# Altium schematic sheet 419-005-01-02_PG 10 - STRAPPING_JTAG_SD_&_Terminal.SchDoc("419-005-01-02_PG 10 - STRAPPING_JTAG_SD_&_Terminal")
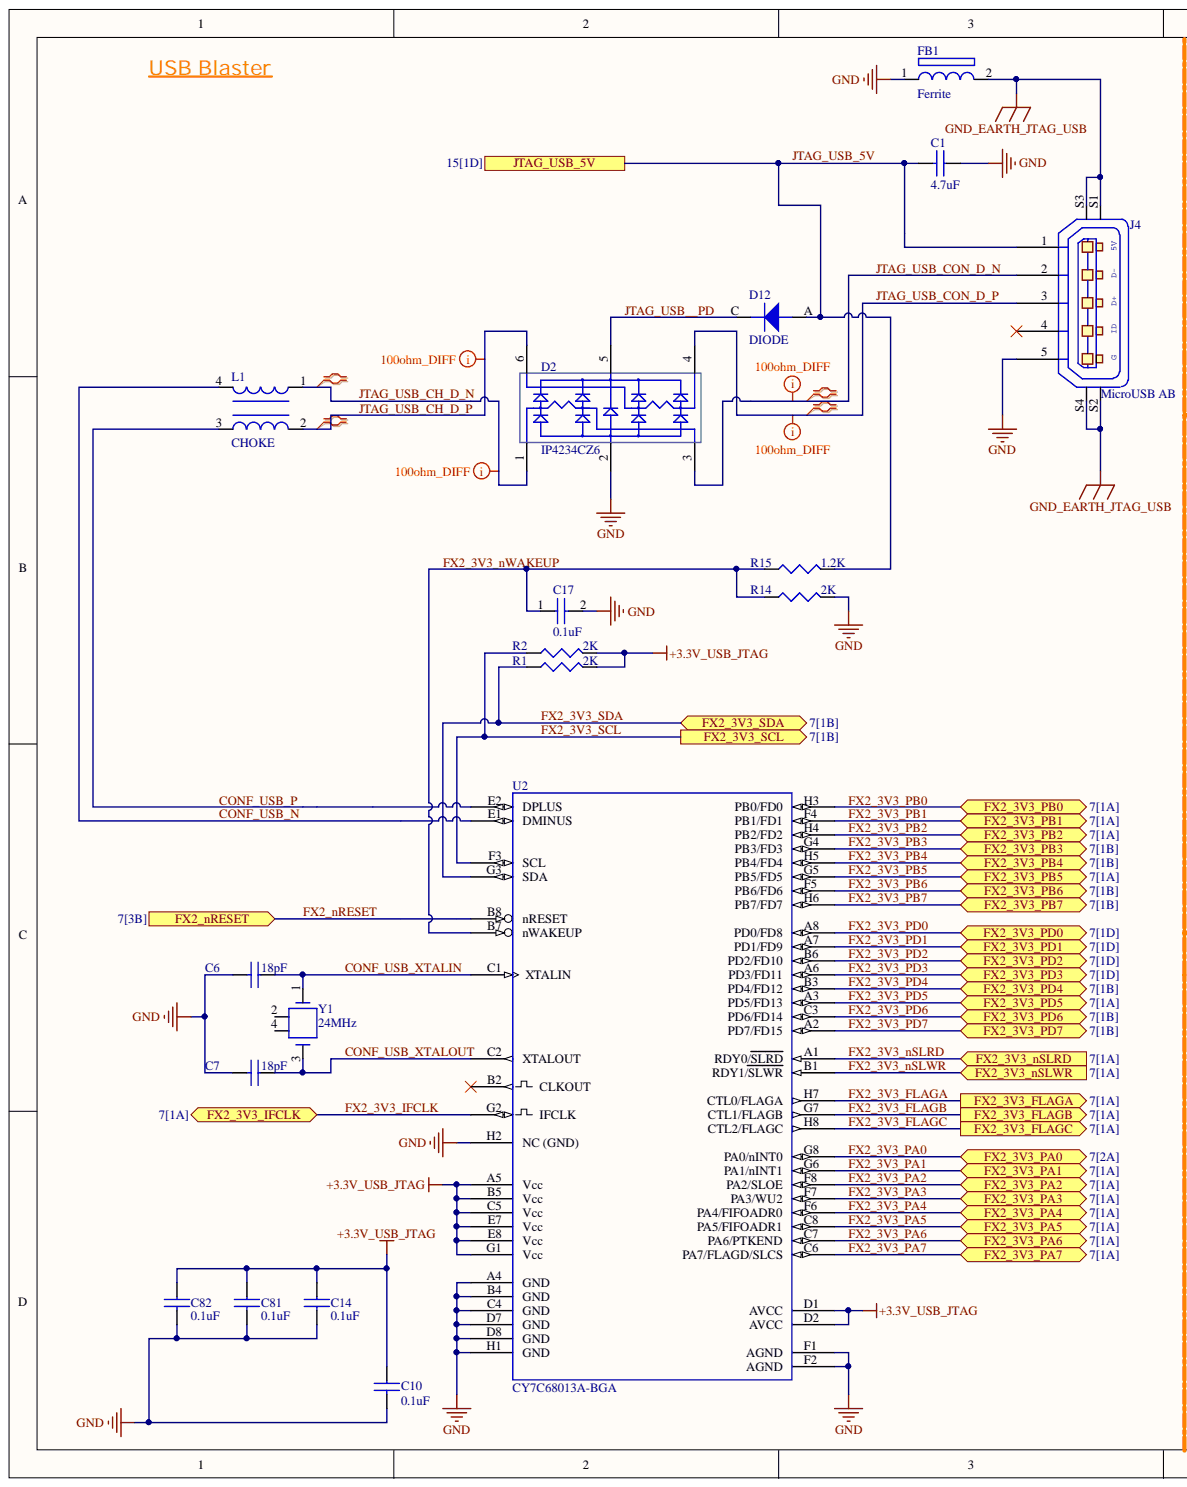
[diagram p0: 419-005-01-02_PG 10 - STRAPPING_JTAG_SD_&_Terminal.SchDoc, left]
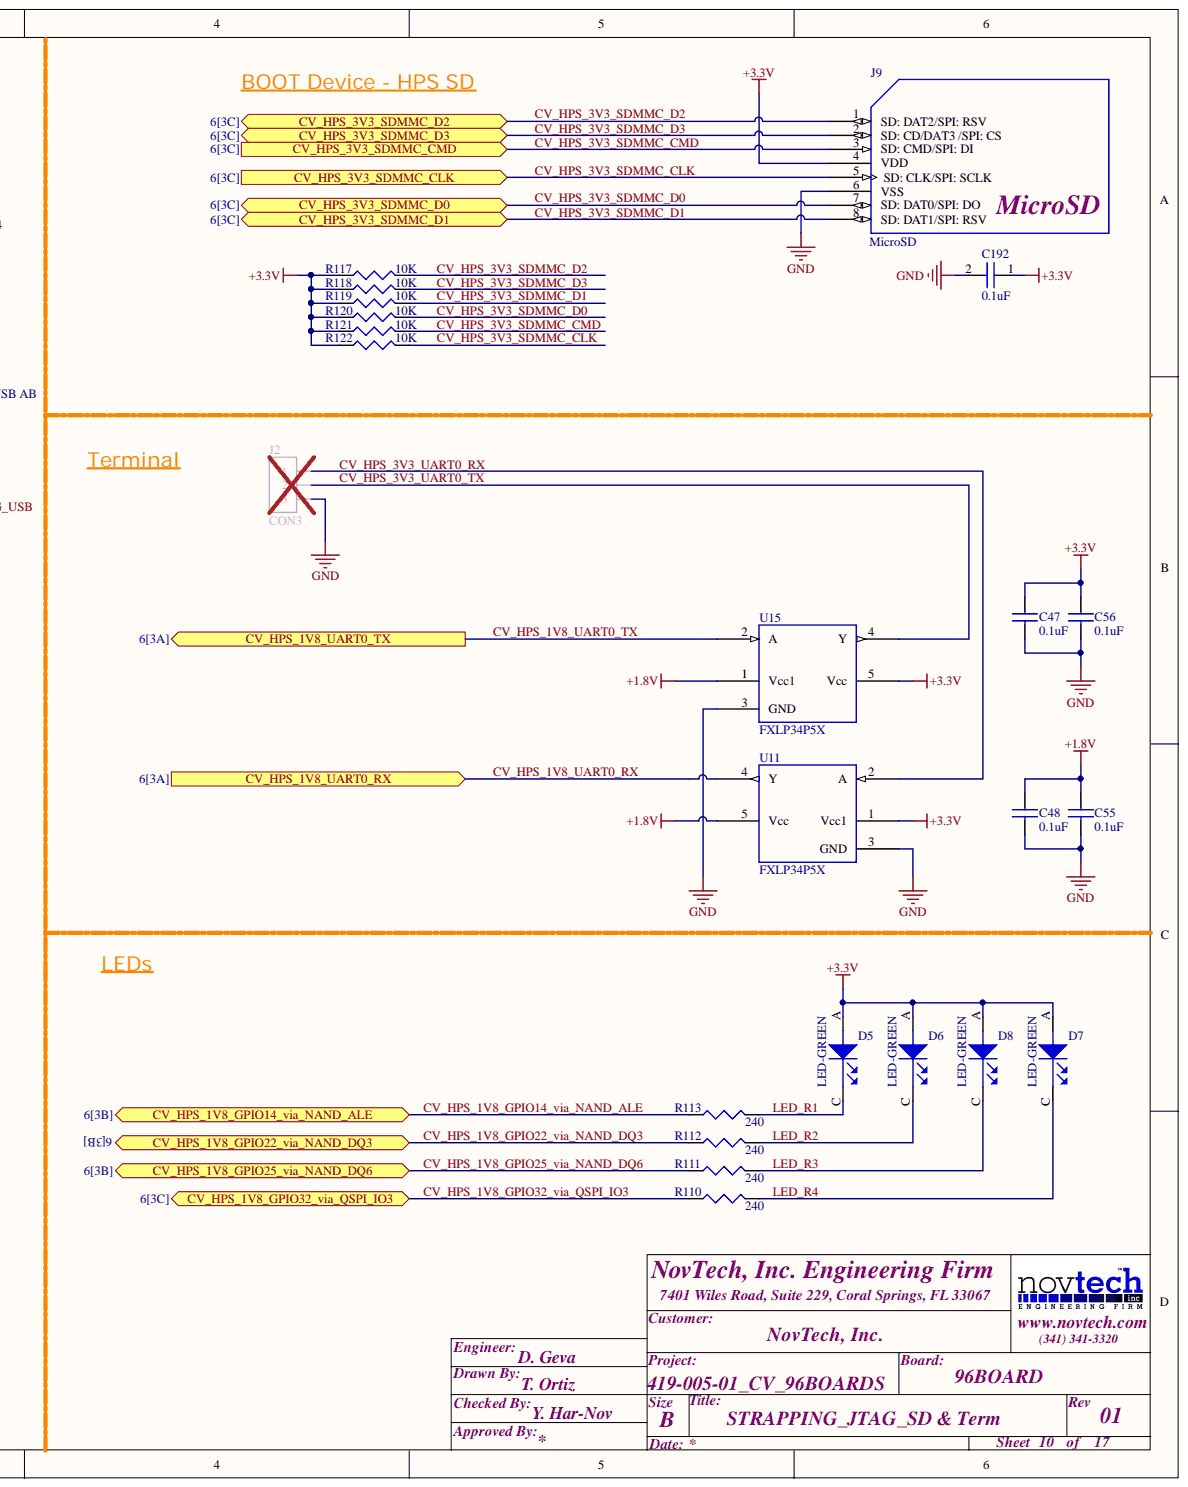
[diagram p1: 419-005-01-02_PG 10 - STRAPPING_JTAG_SD_&_Terminal.SchDoc, right]

## Parts
C1  4.7uF  [0402_C]
C6  18pF  [0201_C]
C7  18pF  [0201_C]
C10  0.1uF  [0201_C]
C14  0.1uF  [0201_C]
C17  0.1uF  [0201_C]
C47  0.1uF  [0201_C]
C48  0.1uF  [0201_C]
C55  0.1uF  [0201_C]
C56  0.1uF  [0201_C]
C81  0.1uF  [0201_C]
C82  0.1uF  [0201_C]
C192  0.1uF  [0201_C]
D2  IP4234CZ6  [SC-74 (SOT457)]
D5  LED-GREEN  [0603_LED]
D6  LED-GREEN  [0603_LED]
D7  LED-GREEN  [0603_LED]
D8  LED-GREEN  [0603_LED]
D12  DIODE  [0402_D]
FB1  Ferrite  [0402_R]
J2  CON3
J4  MicroUSB AB  [MICROUSB_AB_475890001]
J9  MicroSD  [MicroSD - MSPN09-08-D]
L1  CHOKE  [CHOKE_ACM2012_0805]
R1  2K  [0201_R]
R2  2K  [0201_R]
R14  2K  [0201_R]
R15  1.2K  [0201_R]
R110  240  [0201_R]
R111  240  [0201_R]
R112  240  [0201_R]
R113  240  [0201_R]
R117  10K  [0201_R]
R118  10K  [0201_R]
R119  10K  [0201_R]
R120  10K  [0201_R]
R121  10K  [0201_R]
R122  10K  [0201_R]
U2  CY7C68013A-BGA  [VFBGA56 (5.0mmX5.0mm 0.5mm)]
U11  FXLP34P5X  [SC70-5 DCK]
U15  FXLP34P5X  [SC70-5 DCK]
Y1  24MHz  [OSC_SMT_1.6X1.2]

## Ports
CV_HPS_1V8_GPIO14_VIA_NAND_ALE, CV_HPS_1V8_GPIO22_VIA_NAND_DQ3, CV_HPS_1V8_GPIO25_VIA_NAND_DQ6, CV_HPS_1V8_GPIO32_VIA_QSPI_IO3, CV_HPS_1V8_UART0_RX, CV_HPS_1V8_UART0_TX, CV_HPS_3V3_SDMMC_CLK, CV_HPS_3V3_SDMMC_CMD, CV_HPS_3V3_SDMMC_D0, CV_HPS_3V3_SDMMC_D1, CV_HPS_3V3_SDMMC_D2, CV_HPS_3V3_SDMMC_D3, FX2_3V3_FLAGA, FX2_3V3_FLAGB, FX2_3V3_FLAGC, FX2_3V3_IFCLK, FX2_3V3_NSLRD, FX2_3V3_NSLWR, FX2_3V3_PA0, FX2_3V3_PA1, FX2_3V3_PA2, FX2_3V3_PA3, FX2_3V3_PA4, FX2_3V3_PA5, FX2_3V3_PA6, FX2_3V3_PA7, FX2_3V3_PB0, FX2_3V3_PB1, FX2_3V3_PB2, FX2_3V3_PB3, FX2_3V3_PB4, FX2_3V3_PB5, FX2_3V3_PB6, FX2_3V3_PB7, FX2_3V3_PD0, FX2_3V3_PD1, FX2_3V3_PD2, FX2_3V3_PD3, FX2_3V3_PD4, FX2_3V3_PD5, FX2_3V3_PD6, FX2_3V3_PD7, FX2_3V3_SCL, FX2_3V3_SDA, FX2_NRESET, JTAG_USB_5V

## Nets
+1.8V: C48.1 C55.1 U11.5(Vcc) U15.1(Vcc1)
+3.3V: C47.1 C56.1 C192.1 D5.A D6.A D7.A D8.A J9.4(VDD) R117.1 R118.1 R119.1 R120.1 R121.1 R122.1 U11.1(Vcc1) U15.5(Vcc)
+3.3V_USB_JTAG: C10.1 C14.1 C81.1 C82.1 R1.2 R2.2 U2.A5(Vcc) U2.B5(Vcc) U2.C5(Vcc) U2.D1(AVCC) U2.D2(AVCC) U2.E7(Vcc) U2.E8(Vcc) U2.G1(Vcc)
GND: C1.1 C6.2 C7.2 C10.2 C14.2 C17.2 C47.2 C48.2 C55.2 C56.2 C81.2 C82.2 C192.2 D2.2 FB1.1 J2.3 J4.5 J9.6(VSS) R14.2 U2.A4(GND) U2.B4(GND) U2.C4(GND) U2.D7(GND) U2.D8(GND) U2.F1(AGND) U2.F2(AGND) U2.H1(GND) U2.H2(NC_(GND)) U11.3(GND) U15.3(GND)
GND_EARTH_JTAG_USB: FB1.2 J4.S1 J4.S2 J4.S3 J4.S4
CONF_USB_N: L1.4 U2.E1(DMINUS)
CONF_USB_P: L1.3 U2.E2(DPLUS)
CONF_USB_XTALIN: C6.1 U2.C1(XTALIN) Y1.1
CONF_USB_XTALOUT: C7.1 U2.C2(XTALOUT) Y1.3
CV_HPS_1V8_GPIO14_VIA_NAND_ALE: R113.1 ; port CV_HPS_1V8_GPIO14_VIA_NAND_ALE
CV_HPS_1V8_GPIO22_VIA_NAND_DQ3: R112.1 ; port CV_HPS_1V8_GPIO22_VIA_NAND_DQ3
CV_HPS_1V8_GPIO25_VIA_NAND_DQ6: R111.1 ; port CV_HPS_1V8_GPIO25_VIA_NAND_DQ6
CV_HPS_1V8_GPIO32_VIA_QSPI_IO3: R110.1 ; port CV_HPS_1V8_GPIO32_VIA_QSPI_IO3
CV_HPS_1V8_UART0_RX: U11.4(Y) ; port CV_HPS_1V8_UART0_RX
CV_HPS_1V8_UART0_TX: U15.2(A) ; port CV_HPS_1V8_UART0_TX
CV_HPS_3V3_SDMMC_CLK: J9.5(SD:_CLK/SPI:_SCLK) R122.2 ; port CV_HPS_3V3_SDMMC_CLK
CV_HPS_3V3_SDMMC_CMD: J9.3(SD:_CMD/SPI:_DI) R121.2 ; port CV_HPS_3V3_SDMMC_CMD
CV_HPS_3V3_SDMMC_D0: J9.7(SD:_DAT0/SPI:_DO) R120.2 ; port CV_HPS_3V3_SDMMC_D0
CV_HPS_3V3_SDMMC_D1: J9.8(SD:_DAT1/SPI:_RSV) R119.2 ; port CV_HPS_3V3_SDMMC_D1
CV_HPS_3V3_SDMMC_D2: J9.1(SD:_DAT2/SPI:_RSV) R117.2 ; port CV_HPS_3V3_SDMMC_D2
CV_HPS_3V3_SDMMC_D3: J9.2(SD:_CD/DAT3_/SPI:_CS) R118.2 ; port CV_HPS_3V3_SDMMC_D3
CV_HPS_3V3_UART0_RX: J2.1 U11.2(A)
CV_HPS_3V3_UART0_TX: J2.2 U15.4(Y)
FX2_3V3_FLAGA: U2.H7(CTL0/FLAGA) ; port FX2_3V3_FLAGA
FX2_3V3_FLAGB: U2.G7(CTL1/FLAGB) ; port FX2_3V3_FLAGB
FX2_3V3_FLAGC: U2.H8(CTL2/FLAGC) ; port FX2_3V3_FLAGC
FX2_3V3_IFCLK: U2.G2 ; port FX2_3V3_IFCLK
FX2_3V3_NSLRD: U2.A1(RDY0/SLRD) ; port FX2_3V3_NSLRD
FX2_3V3_NSLWR: U2.B1(RDY1/SLWR) ; port FX2_3V3_NSLWR
FX2_3V3_NWAKEUP: C17.1 R14.1 R15.1 U2.B7(nWAKEUP)
FX2_3V3_PA0: U2.G8(PA0/nINT0) ; port FX2_3V3_PA0
FX2_3V3_PA1: U2.G6(PA1/nINT1) ; port FX2_3V3_PA1
FX2_3V3_PA2: U2.F8(PA2/SLOE) ; port FX2_3V3_PA2
FX2_3V3_PA3: U2.F7(PA3/WU2) ; port FX2_3V3_PA3
FX2_3V3_PA4: U2.F6(PA4/FIFOADR0) ; port FX2_3V3_PA4
FX2_3V3_PA5: U2.C8(PA5/FIFOADR1) ; port FX2_3V3_PA5
FX2_3V3_PA6: U2.C7(PA6/PTKEND) ; port FX2_3V3_PA6
FX2_3V3_PA7: U2.C6(PA7/FLAGD/SLCS) ; port FX2_3V3_PA7
FX2_3V3_PB0: U2.H3(PB0/FD0) ; port FX2_3V3_PB0
FX2_3V3_PB1: U2.F4(PB1/FD1) ; port FX2_3V3_PB1
FX2_3V3_PB2: U2.H4(PB2/FD2) ; port FX2_3V3_PB2
FX2_3V3_PB3: U2.G4(PB3/FD3) ; port FX2_3V3_PB3
FX2_3V3_PB4: U2.H5(PB4/FD4) ; port FX2_3V3_PB4
FX2_3V3_PB5: U2.G5(PB5/FD5) ; port FX2_3V3_PB5
FX2_3V3_PB6: U2.F5(PB6/FD6) ; port FX2_3V3_PB6
FX2_3V3_PB7: U2.H6(PB7/FD7) ; port FX2_3V3_PB7
FX2_3V3_PD0: U2.A8(PD0/FD8) ; port FX2_3V3_PD0
FX2_3V3_PD1: U2.A7(PD1/FD9) ; port FX2_3V3_PD1
FX2_3V3_PD2: U2.B6(PD2/FD10) ; port FX2_3V3_PD2
FX2_3V3_PD3: U2.A6(PD3/FD11) ; port FX2_3V3_PD3
FX2_3V3_PD4: U2.B3(PD4/FD12) ; port FX2_3V3_PD4
FX2_3V3_PD5: U2.A3(PD5/FD13) ; port FX2_3V3_PD5
FX2_3V3_PD6: U2.C3(PD6/FD14) ; port FX2_3V3_PD6
FX2_3V3_PD7: U2.A2(PD7/FD15) ; port FX2_3V3_PD7
FX2_3V3_SCL: R2.1 U2.F3(SCL) ; port FX2_3V3_SCL
FX2_3V3_SDA: R1.1 U2.G3(SDA) ; port FX2_3V3_SDA
FX2_NRESET: U2.B8(nRESET) ; port FX2_NRESET
JTAG_USB_5V: C1.2 D12.A J4.1 R15.2 ; port JTAG_USB_5V
JTAG_USB__PD: D2.5 D12.C
JTAG_USB_CH_D_N: D2.1 L1.1
JTAG_USB_CH_D_P: D2.6 L1.2
JTAG_USB_CON_D_N: D2.3 J4.2
JTAG_USB_CON_D_P: D2.4 J4.3
LED_R1: D5.C R113.2
LED_R2: D6.C R112.2
LED_R3: D8.C R111.2
LED_R4: D7.C R110.2

Not connected: J4 4; U2 B2; Y1 2 4

## Library data of the parts
C1 = CAP_0402: Capasitor 0402 footprint; Bottom: 10; Right: 20; Component Kind: Standard; Library Name: PASSIVES.SchLib
C6 C7 C10 C14 C17 C47 C48 C55 C56 C81 C82 C192 = CAP_0201: Capasitor 0201 footprint; Component Kind: Standard; Library Name: PASSIVES.SchLib
D2 = IP4234CZ6: PART: IP4234CZ6,125; MFG: NXP; TEMP: -40°C to +85°C; TYPE: SMT; Component Kind: Standard; DigiKey: 568-5869-1-ND; Library Name: PASSIVES.SchLib; RoHS: Yes
D5-D8 = LED: Bottom: 20; Right: 40; Component Kind: Standard; Library Name: PASSIVES.SchLib
D12 = DIODE: PART: CDSQR4148; Marking: S3; MFG: Comchip; TEMP: +125°C; TYPE: SMT; Component Kind: Standard; DigiKey: 641-1287-2-ND; Library Name: PASSIVES.SchLib; RoHS: Yes
FB1 = INDUCTOR_BEAD: TEMP: -55°C to +85°C; TYPE: SMT; Component Kind: Standard; Library Name: PASSIVES.SchLib; RoHS: Yes
J4 = USB: PART: 0475890001; MFG: Molex; Component Kind: Standard; DigiKey: WM17143CT-ND; Library Name: CONNECTORS_MECHANICAL.SchLib; RoHS: Yes; TYPE: SMT
L1 = CHOCKE: PART: ACM2012-900-2P-T001; MFG: TDK; TEMP: -40°C to +85°C; TYOE: SMT; Component Kind: Standard; Digikey: 445-15969-1-ND; Library Name: PASSIVES.SchLib; RoHS: Yes
R1 R2 R14 = RESISOTR 0201: Resistor 0201 footprint; PART: ERJ-1GEF2001C; MFG: Panasonic; Component Kind: Standard; Digikey: P2.00KABCT-ND; Library Name: PASSIVES.SchLib; RoHS: Yes; TYPE: SMT
R15 = RESISOTR 0201: Resistor 0201 footprint; PART: ERJ-1GEF1201C; MFG: Panasonic; Component Kind: Standard; Digikey: P1.20KABCT-ND; Library Name: PASSIVES.SchLib; RoHS: Yes; TYPE: SMT
R110-R113 = RESISOTR 0201: Resistor 0201 footprint; PART: ERJ-1GEF2400C; MFG: Panasonic; Component Kind: Standard; Digikey: P240ABTR-ND; Library Name: PASSIVES.SchLib; RoHS: Yes; TYPE: SMT
R117-R122 = RESISOTR 0201: Resistor 0201 footprint; PART: ERJ-1GEF1002C; MFG: Panasonic; Component Kind: Standard; Digikey: P10.0KABCT-ND; Library Name: PASSIVES.SchLib; RoHS: Yes; TYPE: SMT
U2 = CY7C68013A_BGA: EZ-USB FX2LP USB Microcontroller; PART: CY7C68013A-56BAXC; MFG: CYPRESS; TEMP: 0° to +70°; Component Kind: Standard; DigiKey: 428-2264-ND; Library Name: IC_SchLib.SCHLIB; RoHS: Yes; TYPE: SMT
U11 U15 = FXLP34P5X: Sigle Bit Uni-Directional Translator; MARKING: P5X; MFG: Fairchild; TEMP: -40°C to +85°C; Component Kind: Standard; Digikey: FXLP34P5XCT-ND; Library Name: IC_SchLib.SCHLIB; PART: FXLP34P5X; RoHS: Yes
Y1 = CRYSTAL_13: PART: 416F24022IKR; MFG: CTX; TEMP: -40° to +85°C; Component Kind: Standard; Digikey: CTX1416CT-ND; Library Name: PASSIVES.SchLib; RoHS: Yes; TYPE: SMT
J2 = CON3: Component Kind: Standard; Library Name: CONNECTORS_MECHANICAL.SchLib
J9 = MicroSD: Component Kind: Standard; Library Name: CONNECTORS_MECHANICAL.SchLib; RoHS: Yes
